# Altium schematic sheet hall.SchDoc
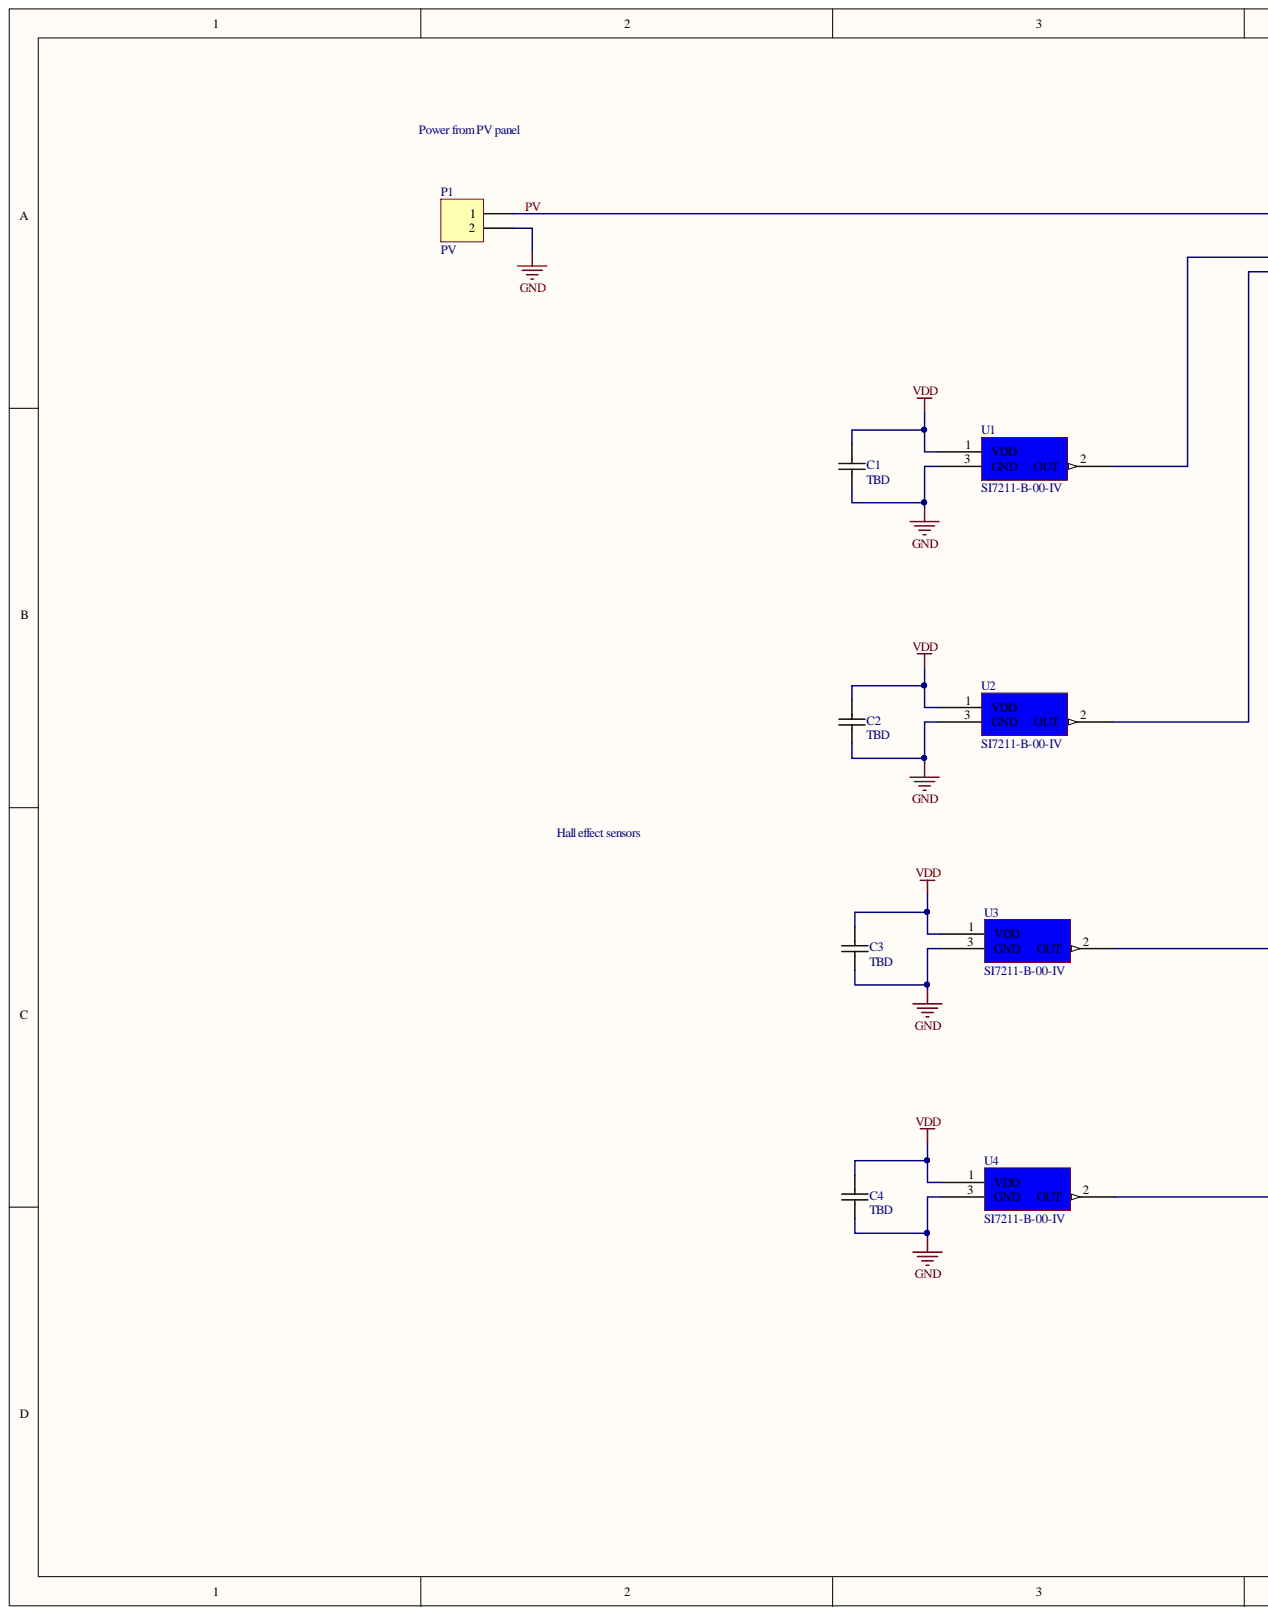
[diagram p0: hall.SchDoc, left]
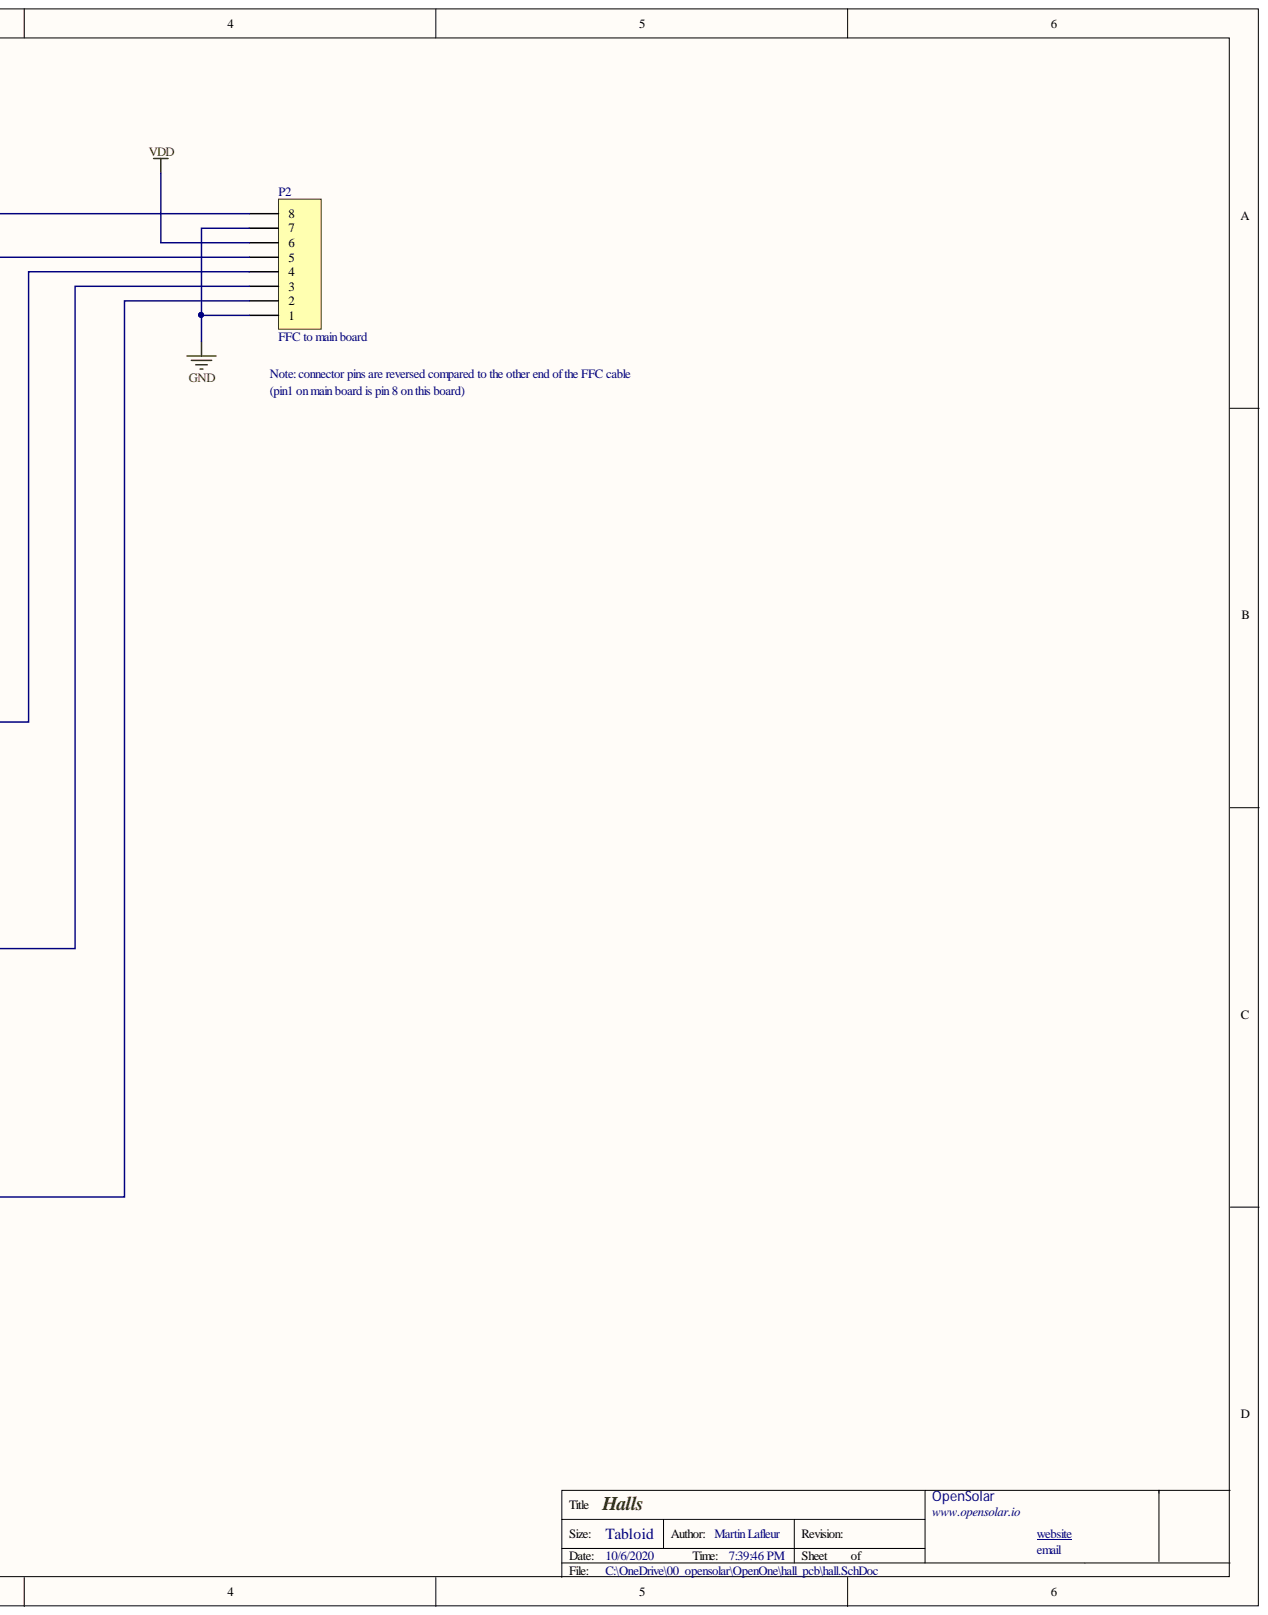
[diagram p1: hall.SchDoc, right]

## Parts
C1  TBD  [0805C-TBD]
C2  TBD  [0805C-TBD]
C3  TBD  [0805C-TBD]
C4  TBD  [0805C-TBD]
P1  PV  [HDR1X2]
P2  FFC to main board  [FFC_8]
U1  SI7211-B-00-IV  [SOT23_914]
U2  SI7211-B-00-IV  [SOT23_914]
U3  SI7211-B-00-IV  [SOT23_914]
U4  SI7211-B-00-IV  [SOT23_914]

## Nets
GND: C1.2 C2.2 C3.2 C4.2 P1.2 P2.1 P2.7 U1.3 U2.3 U3.3 U4.3
VDD: C1.1 C2.1 C3.1 C4.1 P2.6 U1.1 U2.1 U3.1 U4.1
PV: P1.1 P2.8
NetP2_2: P2.2 U4.2
NetP2_3: P2.3 U3.2
NetP2_4: P2.4 U2.2
NetP2_5: P2.5 U1.2

## Library data of the parts
C1-C4 = 0805C-TBD: CAPACITOR 0805 CERAMIC VALUE TO BE DETERMINED; Component Kind: Standard; Library Name: vh_altium_library.IntLib
P1 = Header 2: Header, 2-Pin; Component Kind: Standard; LatestRevisionDate: 17-Jul-2002; LatestRevisionNote: Re-released for DXP Platform.; Library Name: Miscellaneous Connectors.IntLib; Signal Integrity: Connector; Publisher: Altium Limited
P2 = Header 8: Header, 8-Pin; Component Kind: Standard; LatestRevisionDate: 17-Jul-2002; LatestRevisionNote: Re-released for DXP Platform.; Library Name: Miscellaneous Connectors.IntLib; Publisher: Altium Limited
U1-U4 = SI7211-B-00: Hall effect analog sensor; Component Kind: Standard; Library Name: laf.SchLib
